# Altium schematic sheet ADC Chip.SchDoc
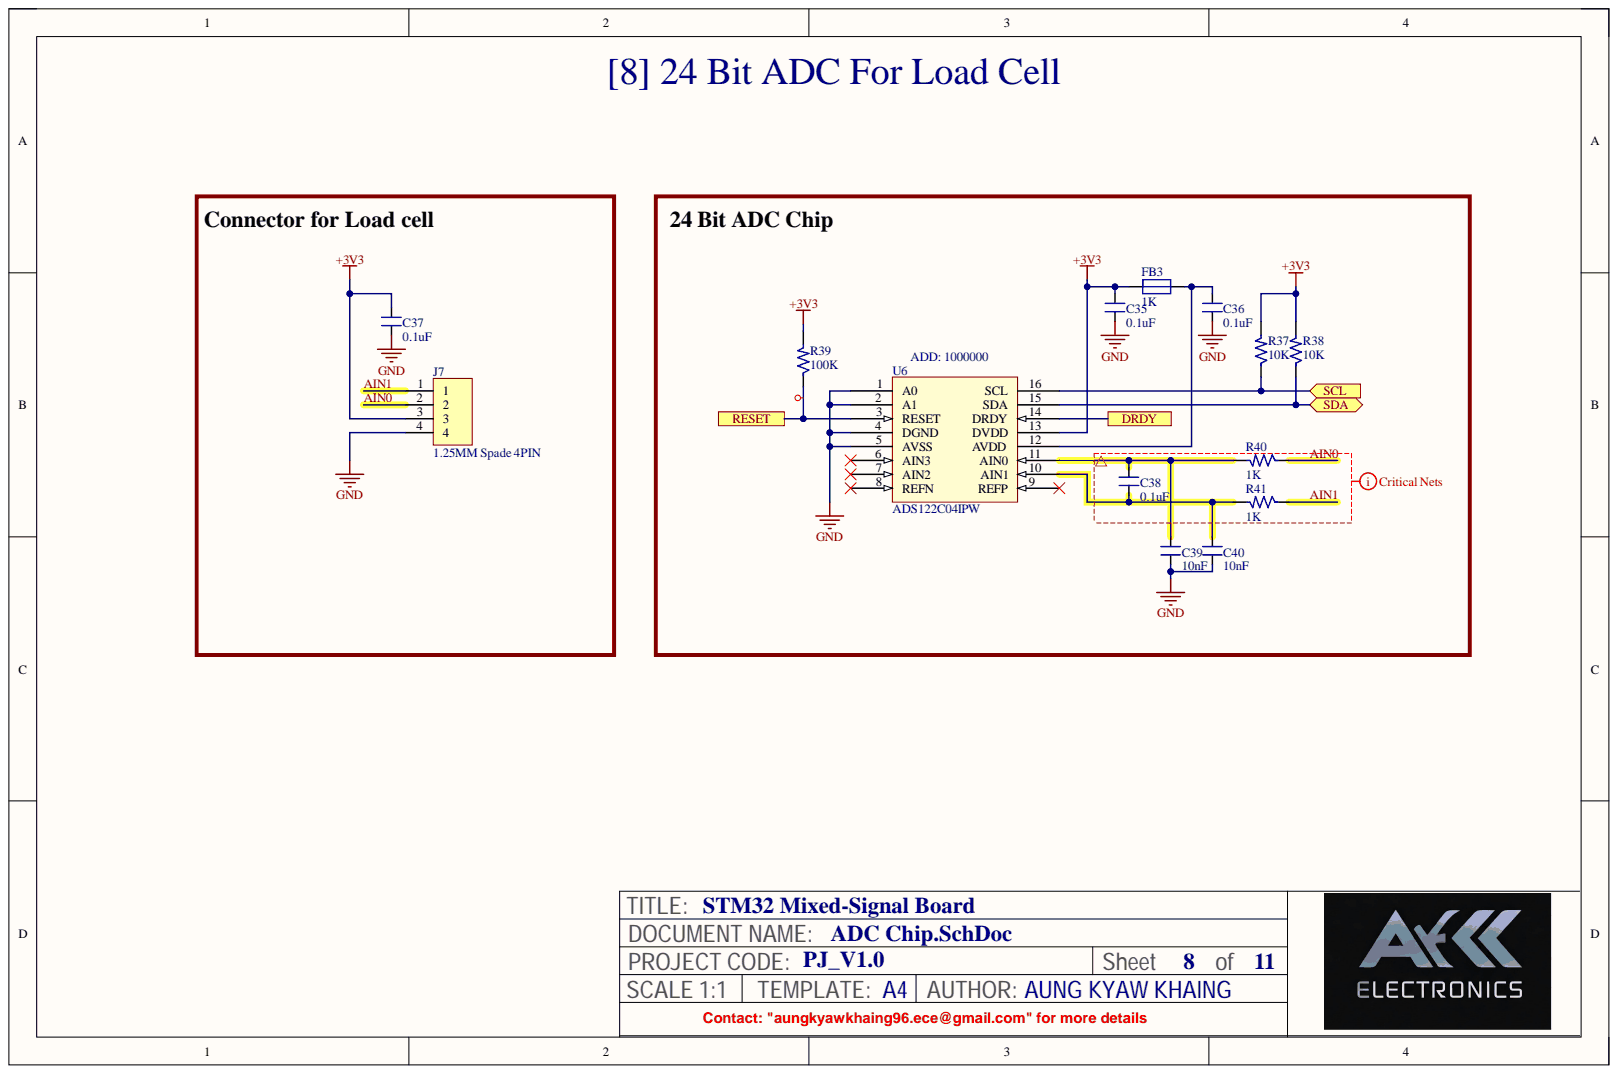
[diagram p0: ADC Chip.SchDoc]

## Parts
C35  0.1uF  [CAP_0805]
C36  0.1uF  [CAP_0805]
C37  0.1uF  [CAP_0805]
C38  0.1uF  [CAP_0805]
C39  10nF  [CAP_0805]
C40  10nF  [CAP_0805]
FB3  1K  [IND_0805]
J7  1.25MM Spade 4PIN  [CON4_50_653004117322_TH_RA]
R37  10K  [RES_0603]
R38  10K  [RES_0603]
R39  100K  [RES_0603]
R40  1K  [RES_0603]
R41  1K  [RES_0603]
U6  ADS122C04IPW  [TSSOP16_25-59_294_54X14_SMT]

## Ports
DRDY, RESET, SCL, SDA

## Nets
+3V3: C35.2 C37.2 FB3.1 J7.3 R37.2 R38.2 R39.2 U6.13(DVDD)
GND: C35.1 C36.1 C37.1 C39.1 C40.1 J7.4 U6.1(A0) U6.2(A1) U6.4(DGND) U6.5(AVSS)
AIN0: J7.2 R40.1
AIN1: J7.1 R41.1
DRDY: U6.14(DRDY) ; port DRDY
RESET: R39.1 U6.3(RESET) ; port RESET
SCL: R37.1 U6.16(SCL) ; port SCL
SDA: R38.1 U6.15(SDA) ; port SDA
NetC36_2: C36.2 FB3.2 U6.12(AVDD)
NetC38_1: C38.1 C40.2 R41.2 U6.10(AIN1)
NetC38_2: C38.2 C39.2 R40.2 U6.11(AIN0)

Not connected: U6 6(AIN3) 7(AIN2) 8(REFN) 9(REFP)

## Library data of the parts
C35-C38 = CAP_0.1uF-50V-10%-0805: 0.1µF ±10% 50V Ceramic Capacitor X7R 0805 (2012 Metric); Alternate Part Number-1: NA; Manufacturer: Samsung; Manufacturer Part Number: CL21B104KBCNNNC; Case/Package: 0805; Component Kind: Standard; Library Name: ESTEEMPCBLIB.IntLib; Supplier Part Number-1: 0805B104K500CT; Supplier-1: SIRICOM
C39 C40 = CAP_10nF-50V-10%-0805: 10000pF ±10% 50V Ceramic Capacitor X7R 0805 (2012 Metric); Alternate Part Number-1: GRM2195C1H103JA01D; Manufacturer: Samsung; Manufacturer Part Number: C0805C103K5RECAUTO; Case/Package: 0805; Component Kind: Standard; Library Name: ESTEEMPCBLIB.IntLib; Supplier Part Number-1: 0805B103K500CT; Supplier-1: SIRICOM
FB3 = FB_1K-100MHZ-1.6A-0805: 1 kOhms @ 100MHz 1 Power Line Ferrite Bead 0805 (2012 Metric) 1.6A; Alternate Part Number-1: NA; Manufacturer: Laird; Manufacturer Part Number: BLM21SP102SH1D; Case/Package: 0805; Component Kind: Standard; Library Name: ESTEEMPCBLIB.IntLib; Supplier Part Number-1: ACMS201209A102; Supplier-1: SIRICOM
J7 = CON-PLG_4X1-50-RA-TH: 4 Pins, 1 Row 1.25mm Pitch, right angle Connector, male; Alternate Part Number-1: NA; Crimp Part Number: 653153128130; Manufacturer: JST; Manufacturer Part Number: 653004117322; Mating Part Number: 653004113322; Case/Package: TH-CON; Component Kind: Standard; Library Name: ESTEEMPCBLIB.IntLib; Supplier Part Number-1: 653004117322; Supplier-1: WURTH
R37 R38 = RES_10K-0.1W-1%-0603: 10 kOhms ±1% 0.1W, 1/10W Chip Resistor 0603 (1608 Metric) Automotive AEC-Q200 Thick Film; Alternate Part Number-1: 0603WAJ0103T5E; Manufacturer: Walsin; Manufacturer Part Number: WR06X1002FTL; Case/Package: 0603; Component Kind: Standard; Library Name: ESTEEMPCBLIB.IntLib; Supplier Part Number-1: WR06X1002FTL; Supplier-1: SIRICOM
R39 = RES_100K-0.1W-1%-0603: 100 kOhms ±1% 0.1W, 1/10W Chip Resistor 0603 (1608 Metric) Automotive AEC-Q200 Thick Film; Alternate Part Number-1: NA; Manufacturer: Stackpole Electronics; Manufacturer Part Number: RMCF0603FT100K; Case/Package: 0603; Component Kind: Standard; Library Name: ESTEEMPCBLIB.IntLib; Supplier Part Number-1: RMCF0603FT100K; Supplier-1: Arrow
R40 R41 = RES_1K-0.1W-1%-0603: 1 kOhms ±1% 0.1W, 1/10W Chip Resistor 0603 (1608 Metric) Automotive AEC-Q200 Thick Film; Alternate Part Number-1: 0603WAF1001T5E; Manufacturer: Walsin; Manufacturer Part Number: WR06X1001FTL; Case/Package: 0603; Component Kind: Standard; Library Name: ESTEEMPCBLIB.IntLib; Supplier Part Number-1: WR06X1001FTL; Supplier-1: SIRICOM
U6 = IC_ADS122C04IPW: 24 Bit Analog to Digital Converter 4 Input 1 Sigma-Delta 16-TSSOP; Alternate Part Number-1: NA; Manufacturer: Texas Instruments; Case/Package: TSSOP16; Component Kind: Standard; Library Name: ESTEEMPCBLIB.IntLib; Manufacturer Part Number: ADS122C04IPW; Supplier Part Number-1: ADS122C04IPW; Supplier-1: MOUSER
